# Altium schematic sheet MCU045A_Target_Device.SchDoc(MCU045A_Target_Device)
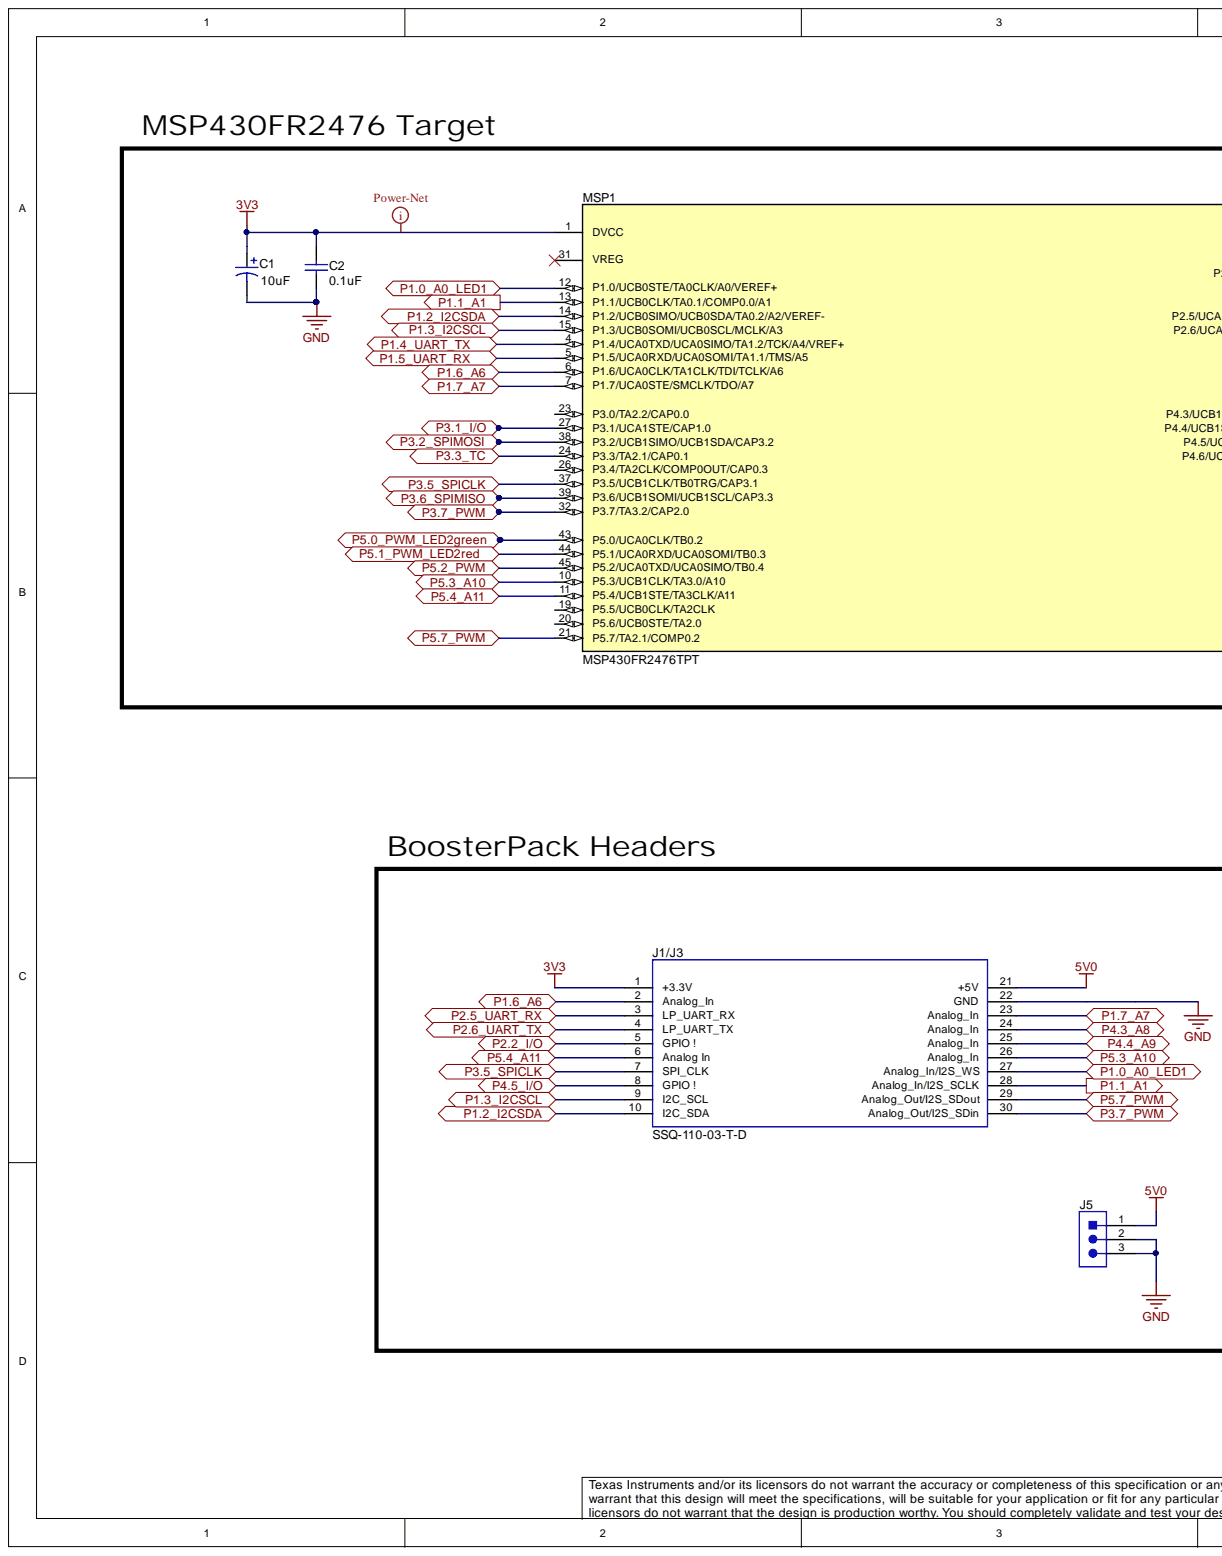
[diagram p0: MCU045A_Target_Device.SchDoc, left]
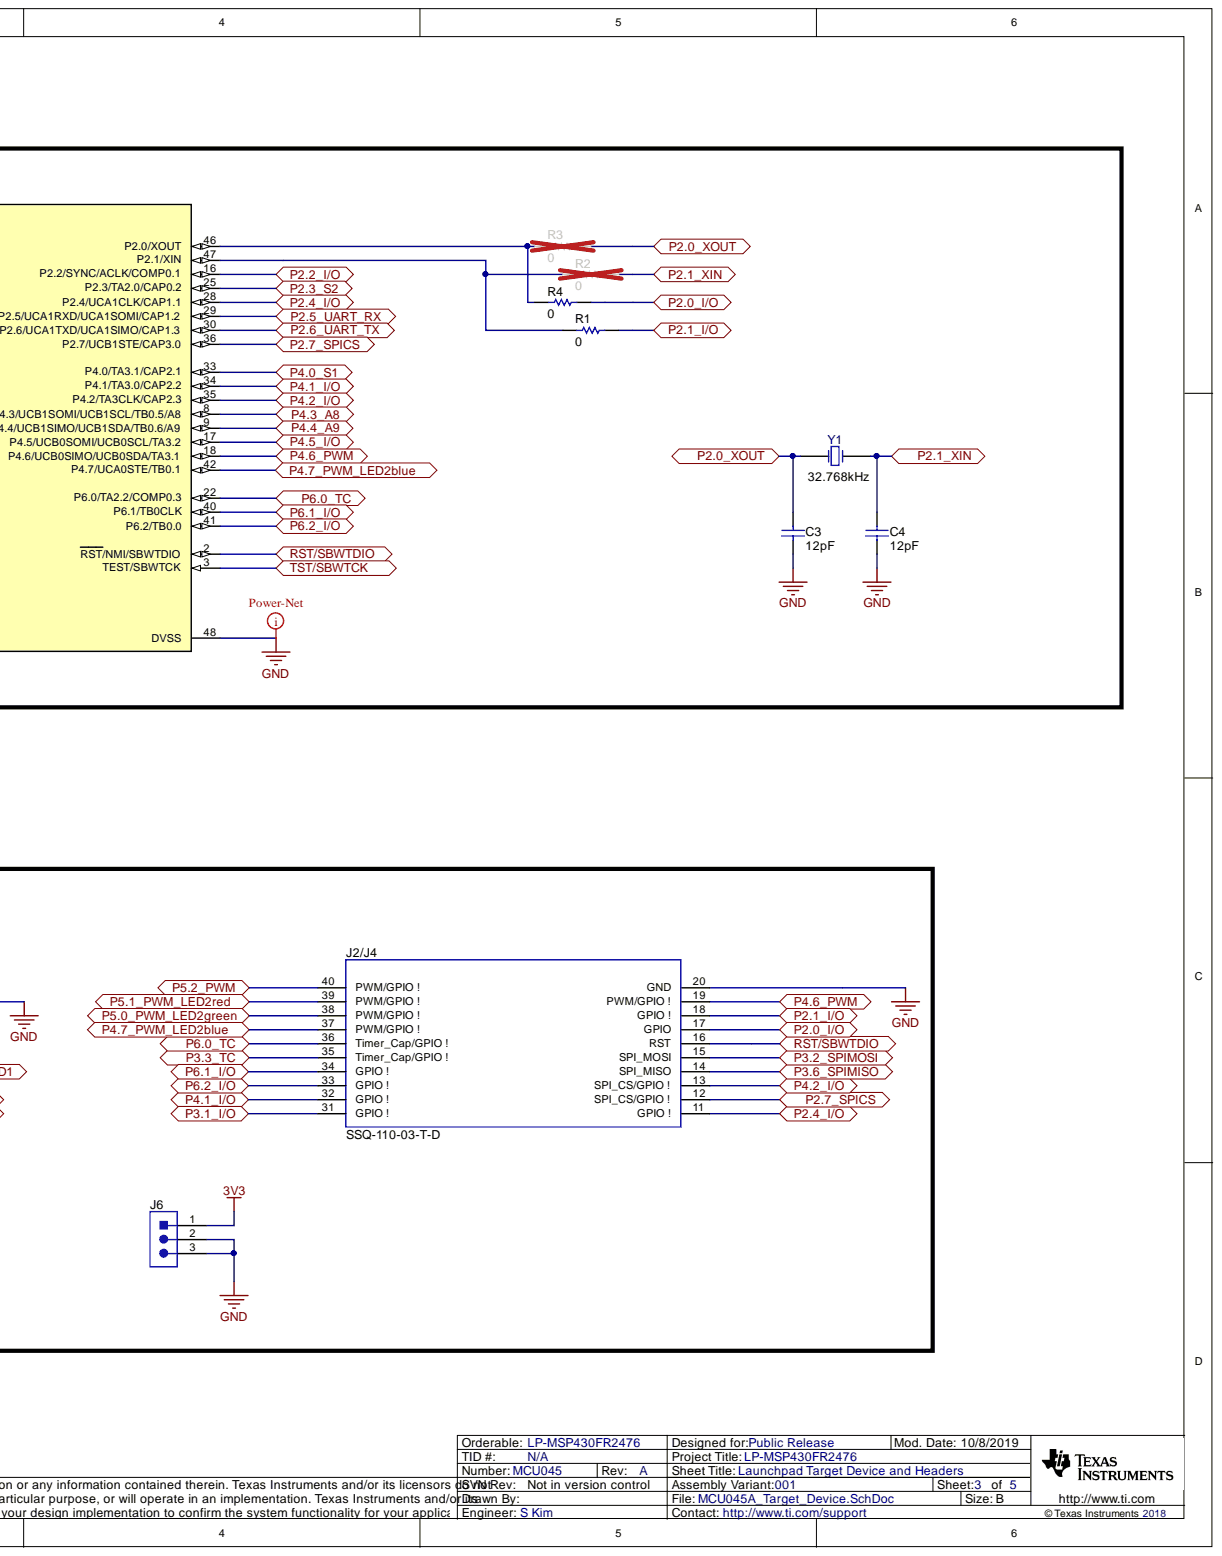
[diagram p1: MCU045A_Target_Device.SchDoc, right]

## Parts
C1  value 10uF  ValueDisplayed: 10uF  [3216-18]  (hidden comment: 293D106X0010A2TE3)
C2  value 0.1uF  ValueDisplayed: 0.1uF  [0402]  (hidden comment: GMK105BJ104KV-F)
C3  value 12pF  ValueDisplayed: 12pF  [0402]  (hidden comment: CL05C120JB5NNNC)
C4  value 12pF  ValueDisplayed: 12pF  [0402]  (hidden comment: CL05C120JB5NNNC)
J1/J3  SSQ-110-03-T-D  [BoosterPack_40pin_J1J3]
J2/J4  SSQ-110-03-T-D  [BoosterPack_40pin_J2J4]
J5  PEC03SAAN  [PEC03SAAN_Launchpad_5V0]  (value not drawn)
J6  PEC03SAAN  [PEC03SAAN_Launchpad_3V3]  (value not drawn)
MSP1  MSP430FR2476TPT  [PT0048A_N]
R1  value 0  [0603]  (hidden comment: CRCW06030000Z0EA)
R2  value 0  [0603]  (hidden comment: CRCW06030000Z0EA)
R3  value 0  [0603]  (hidden comment: CRCW06030000Z0EA)
R4  value 0  [0603]  (hidden comment: CRCW06030000Z0EA)
Y1  Frequency_Fixed: 32.768kHz  [ECS_ECX_31B]  (hidden comment: ECS-.327-12.5-34B-TR)

## Ports
P1.0_A0_LED1, P1.1_A1, P1.2_I2CSDA, P1.3_I2CSCL, P1.4_UART_TX, P1.5_UART_RX, P1.6_A6, P1.7_A7, P2.0_I/O, P2.0_XOUT, P2.1_I/O, P2.1_XIN, P2.2_I/O, P2.3_S2, P2.4_I/O, P2.5_UART_RX, P2.6_UART_TX, P2.7_SPICS, P3.1_I/O, P3.2_SPIMOSI, P3.3_TC, P3.5_SPICLK, P3.6_SPIMISO, P3.7_PWM, P4.0_S1, P4.1_I/O, P4.2_I/O, P4.3_A8, P4.4_A9, P4.5_I/O, P4.6_PWM, P4.7_PWM_LED2blue, P5.0_PWM_LED2green, P5.1_PWM_LED2red, P5.2_PWM, P5.3_A10, P5.4_A11, P5.7_PWM, P6.0_TC, P6.1_I/O, P6.2_I/O, RST/SBWTDIO, TST/SBWTCK

## Nets
3V3: C1.1 C2.1 J1/J3.1(+3.3V) J6.1 MSP1.1(DVCC)
5V0: J1/J3.21(+5V) J5.1
GND: C1.2 C2.2 C3.1 C4.1 J1/J3.22(GND) J2/J4.20(GND) J5.2 J5.3 J6.2 J6.3 MSP1.48(DVSS)
P1.0_A0_LED1: J1/J3.27(Analog_In/I2S_WS) MSP1.12(P1.0/UCB0STE/TA0CLK/A0/VEREF+) ; port P1.0_A0_LED1
P1.1_A1: J1/J3.28(Analog_In/I2S_SCLK) MSP1.13(P1.1/UCB0CLK/TA0.1/COMP0.0/A1) ; port P1.1_A1
P1.2_I2CSDA: J1/J3.10(I2C_SDA) MSP1.14(P1.2/UCB0SIMO/UCB0SDA/TA0.2/A2/VEREF-) ; port P1.2_I2CSDA
P1.3_I2CSCL: J1/J3.9(I2C_SCL) MSP1.15(P1.3/UCB0SOMI/UCB0SCL/MCLK/A3) ; port P1.3_I2CSCL
P1.4_UART_TX: MSP1.4(P1.4/UCA0TXD/UCA0SIMO/TA1.2/TCK/A4/VREF+) ; port P1.4_UART_TX
P1.5_UART_RX: MSP1.5(P1.5/UCA0RXD/UCA0SOMI/TA1.1/TMS/A5) ; port P1.5_UART_RX
P1.6_A6: J1/J3.2(Analog_In) MSP1.6(P1.6/UCA0CLK/TA1CLK/TDI/TCLK/A6) ; port P1.6_A6
P1.7_A7: J1/J3.23(Analog_In) MSP1.7(P1.7/UCA0STE/SMCLK/TDO/A7) ; port P1.7_A7
P2.0_I/O: J2/J4.17(GPIO) R4.2 ; port P2.0_I/O
P2.0_XOUT: C3.2 R3.2 Y1.2 ; port P2.0_XOUT
P2.1_I/O: J2/J4.18(GPIO_!) R1.2 ; port P2.1_I/O
P2.1_XIN: C4.2 R2.2 Y1.1 ; port P2.1_XIN
P2.2_I/O: J1/J3.5(GPIO_!) MSP1.16(P2.2/SYNC/ACLK/COMP0.1) ; port P2.2_I/O
P2.3_S2: MSP1.25(P2.3/TA2.0/CAP0.2) ; port P2.3_S2
P2.4_I/O: J2/J4.11(GPIO_!) MSP1.28(P2.4/UCA1CLK/CAP1.1) ; port P2.4_I/O
P2.5_UART_RX: J1/J3.3(LP_UART_RX) MSP1.29(P2.5/UCA1RXD/UCA1SOMI/CAP1.2) ; port P2.5_UART_RX
P2.6_UART_TX: J1/J3.4(LP_UART_TX) MSP1.30(P2.6/UCA1TXD/UCA1SIMO/CAP1.3) ; port P2.6_UART_TX
P2.7_SPICS: J2/J4.12(SPI_CS/GPIO_!) MSP1.36(P2.7/UCB1STE/CAP3.0) ; port P2.7_SPICS
P3.1_I/O: J2/J4.31(GPIO_!) MSP1.27(P3.1/UCA1STE/CAP1.0) ; port P3.1_I/O
P3.2_SPIMOSI: J2/J4.15(SPI_MOSI) MSP1.38(P3.2/UCB1SIMO/UCB1SDA/CAP3.2) ; port P3.2_SPIMOSI
P3.3_TC: J2/J4.35(Timer_Cap/GPIO_!) MSP1.24(P3.3/TA2.1/CAP0.1) ; port P3.3_TC
P3.5_SPICLK: J1/J3.7(SPI_CLK) MSP1.37(P3.5/UCB1CLK/TB0TRG/CAP3.1) ; port P3.5_SPICLK
P3.6_SPIMISO: J2/J4.14(SPI_MISO) MSP1.39(P3.6/UCB1SOMI/UCB1SCL/CAP3.3) ; port P3.6_SPIMISO
P3.7_PWM: J1/J3.30(Analog_Out/I2S_SDin) MSP1.32(P3.7/TA3.2/CAP2.0) ; port P3.7_PWM
P4.0_S1: MSP1.33(P4.0/TA3.1/CAP2.1) ; port P4.0_S1
P4.1_I/O: J2/J4.32(GPIO_!) MSP1.34(P4.1/TA3.0/CAP2.2) ; port P4.1_I/O
P4.2_I/O: J2/J4.13(SPI_CS/GPIO_!) MSP1.35(P4.2/TA3CLK/CAP2.3) ; port P4.2_I/O
P4.3_A8: J1/J3.24(Analog_In) MSP1.8(P4.3/UCB1SOMI/UCB1SCL/TB0.5/A8) ; port P4.3_A8
P4.4_A9: J1/J3.25(Analog_In) MSP1.9(P4.4/UCB1SIMO/UCB1SDA/TB0.6/A9) ; port P4.4_A9
P4.5_I/O: J1/J3.8(GPIO_!) MSP1.17(P4.5/UCB0SOMI/UCB0SCL/TA3.2) ; port P4.5_I/O
P4.6_PWM: J2/J4.19(PWM/GPIO_!) MSP1.18(P4.6/UCB0SIMO/UCB0SDA/TA3.1) ; port P4.6_PWM
P4.7_PWM_LED2blue: J2/J4.37(PWM/GPIO_!) MSP1.42(P4.7/UCA0STE/TB0.1) ; port P4.7_PWM_LED2blue
P5.0_PWM_LED2green: J2/J4.38(PWM/GPIO_!) MSP1.43(P5.0/UCA0CLK/TB0.2) ; port P5.0_PWM_LED2green
P5.1_PWM_LED2red: J2/J4.39(PWM/GPIO_!) MSP1.44(P5.1/UCA0RXD/UCA0SOMI/TB0.3) ; port P5.1_PWM_LED2red
P5.2_PWM: J2/J4.40(PWM/GPIO_!) MSP1.45(P5.2/UCA0TXD/UCA0SIMO/TB0.4) ; port P5.2_PWM
P5.3_A10: J1/J3.26(Analog_In) MSP1.10(P5.3/UCB1CLK/TA3.0/A10) ; port P5.3_A10
P5.4_A11: J1/J3.6(Analog_In) MSP1.11(P5.4/UCB1STE/TA3CLK/A11) ; port P5.4_A11
P5.7_PWM: J1/J3.29(Analog_Out/I2S_SDout) MSP1.21(P5.7/TA2.1/COMP0.2) ; port P5.7_PWM
P6.0_TC: J2/J4.36(Timer_Cap/GPIO_!) MSP1.22(P6.0/TA2.2/COMP0.3) ; port P6.0_TC
P6.1_I/O: J2/J4.34(GPIO_!) MSP1.40(P6.1/TB0CLK) ; port P6.1_I/O
P6.2_I/O: J2/J4.33(GPIO_!) MSP1.41(P6.2/TB0.0) ; port P6.2_I/O
RST/SBWTDIO: J2/J4.16(RST) MSP1.2(RST/NMI/SBWTDIO) ; port RST/SBWTDIO
TST/SBWTCK: MSP1.3(TEST/SBWTCK) ; port TST/SBWTCK
NetMSP1_46: MSP1.46(P2.0/XOUT) R3.1 R4.1
NetMSP1_47: MSP1.47(P2.1/XIN) R1.1 R2.1

Not connected: MSP1 19(P5.5/UCB0CLK/TA2CLK) 20(P5.6/UCB0STE/TA2.0) 23(P3.0/TA2.2/CAP0.0) 26(P3.4/TA2CLK/COMP0OUT/CAP0.3) 31(VREG)

## Library data of the parts
C1 = CMP-0011447-2: CAP, TA, 10 uF, 10 V, +/- 20%, 3.4 ohm, SMD; Manufacturer: Vishay-Sprague; VDC_V: 10V; Value1: 3.4 ohm; U/M: EA; TaMin_C: -55°C; TaMax_C: 125°C; SizeZ_mm: 1.8; SizeY_mm: 1.6; SizeX_mm: 3.2; SizeAreaWB_mm2: 10.92; SizeArea_mm2: 5.12; Polarized: Y; IRMS_A: 1.5dA; ESR_ohm: 3.4; CompType: Capacitor; Cap_F: 10µF; Cap_Case: A; AEC Category: Q200; Value2: 10V; Tolerance_%: 20%; Technology: TA; Supplier Part Number 3: 74-293D106X0010A2TE3; Supplier Part Number 2: 09K5784; Supplier Part Number 1: 718-1118-1-ND; Supplier 3: Mouser; Supplier 2: Newark; Supplier 1: Digi-Key; Series: 293D; RoHS: Y; PartNumber: 293D106X0010A2TE3; PackageReference: 3216-18; MountType: SMT; Library Name: Altium Vault - TI; LatestRevisionNote: Updated supplier links; LatestRevisionDate: 2017-03-09; ComponentLink1URL: http://www.vishay.com/docs/40002/293d.pdf; ComponentLink1Description: Datasheet link; Component Kind: Standard; AEC Qualified: ?; Publisher: Texas Instruments
C2 = CMP-0006370-2: CAP, CERM, 0.1 uF, 35 V, +/- 10%, X5R, 0402; Manufacturer: Taiyo Yuden; VDC_V: 35V; Value1: Unknown; U/M: EA; TaMin_C: -55°C; TaMax_C: 85°C; SizeZ_mm: 0.6; SizeY_mm: 0.5; SizeX_mm: 1; SizeAreaWB_mm2: 3; Polarized: N; CompType: Capacitor; Cap_F: 100nF; AEC Category: Q200; Value2: 35V; Tolerance_%: 10%; TempCo: X5R; Technology: Ceramic; Supplier Part Number 3: 963-GMK105BJ104KV-F; Supplier Part Number 2: 84R9117; Supplier Part Number 1: 587-1994-1-ND; Supplier 3: Mouser; Supplier 2: Newark; Supplier 1: Digi-Key; SizeArea_mm2: 0.5; RoHS: Y; Published: 2008-06-19; PartNumber: GMK105BJ104KV-F; PackageReference: 0402; MountType: SMT; Library Name: Altium Vault - TI; LatestRevisionDate: 2017-03-09; ComponentLink1URL: http://www.yuden.co.jp/productdata/catalog/en/mlcc_all_e.pdf; ComponentLink1Description: Datasheet link; Component Kind: Standard; Cap_Case: 0402; AEC Qualified: N; AEC Grade: N/A; Publisher: Texas Instruments
C3 C4 = CMP-0006345-2: CAP, CERM, 12 pF, 50 V, +/- 5%, C0G/NP0, 0402; Manufacturer: Samsung Electro-Mechanics; VDC_V: 50V; Value1: Unknown; U/M: EA; TaMin_C: -55°C; TaMax_C: 125°C; SizeZ_mm: 0.6; SizeY_mm: 0.5; SizeX_mm: 1; SizeAreaWB_mm2: 3; Polarized: N; CompType: Capacitor; AEC Category: Q200; Value2: 50V; Tolerance_%: 5%; TempCo: C0G/NP0; Technology: Ceramic; Supplier Part Number 1: 1276-1178-1-ND; Supplier 1: Digi-Key; SizeArea_mm2: 0.5; RoHS: Y; Published: 2008-06-19; PartNumber: CL05C120JB5NNNC; PackageReference: 0402; MountType: SMT; Library Name: Altium Vault - TI; LatestRevisionDate: 2017-03-09; ComponentLink1URL: http://www.samsungsem.com/servlet/FileDownload?type=spec&file=CL05C120JB5NNNC.pdf; ComponentLink1Description: Datasheet link; Component Kind: Standard; Cap_F: 12pF; Cap_Case: 0402; AEC Qualified: N; AEC Grade: N/A; Publisher: Texas Instruments
J1/J3 = CMP-0003837-2: Receptacle, 2.54mm, 10x2, Tin, TH; Manufacturer: Samtec; Alternate PartNumber: CRD-081413-A-G; Alternate Manufacturer: Major League Electronics; Note: Booster Pack 40 pin J1 and J3; Green_REACh: Incomplete; Green_Lifecycle: Active; Supplier Part Number 2: 11P8392; Supplier Part Number 1: SAM1204-10-ND; Supplier 2: Newark; Supplier 1: Digi-Key; RoHS: Y; PartNumber: SSQ-110-03-T-D; PackageReference: 10x2 Receptacle; MountType: TH; Library Name: Altium Vault - TI; Green_EU RoHS-2: Passed; Green_EU RoHS: Passed; CompType: Receptacle; ComponentLink1URL: https://www.samtec.com/ftppub/cpdf/SSQ-1XX-XX-XXX-X-XX-XXX-XX-X-MKT.pdf; ComponentLink1Description: Datasheet Link; Component Kind: Standard
J2/J4 = CMP-0078266-2: Receptacle, 2.54mm, 10x2, Tin, TH; Manufacturer: Samtec; Alternate PartNumber: CRD-081413-A-G; Alternate Manufacturer: Major League Electronics; Note: Booster Pack 40 pin J2 and J4; Green_REACh: Incomplete; Green_Lifecycle: Active; Supplier Part Number 2: 11P8392; Supplier Part Number 1: SAM1204-10-ND; Supplier 2: Newark; Supplier 1: Digi-Key; RoHS: Y; PartNumber: SSQ-110-03-T-D; PackageReference: 10x2 Receptacle; MountType: TH; Library Name: Altium Vault - TI; Green_EU RoHS-2: Passed; Green_EU RoHS: Passed; CompType: Receptacle; ComponentLink1URL: https://www.samtec.com/ftppub/cpdf/SSQ-1XX-XX-XXX-X-XX-XXX-XX-X-MKT.pdf; ComponentLink1Description: Datasheet Link; Component Kind: Standard
J5 J6 = CMP-0002338-1: Header, 100mil, 3x1, Tin, TH; Manufacturer: Sullins Connector Solutions; Voltage_V: 1.8kV; Shrouded: N; Row_Count: 1; Pitch_mm: 2.54; Green_REACh: Incomplete; Green_Lifecycle: Not Set; Gender: Male; Current_A: 3A; CT: FALSE; CNHeader: TRUE; Cert_UL: Y; Supplier Part Number 1: S1012E-03-ND; Supplier 1: Digi-Key; RoHS: Y; PinCount: 3; PartNumber: PEC03SAAN; PackageReference: Header, 3 PIN, 100mil, Tin; Orientation: Vertical; MountType: TH; Library Name: Altium Vault - TI; LatestRevisionDate: 26/05/2017; Green_EU RoHS-2: Incomplete; Green_EU RoHS: Incomplete; ContactFinish: Tin; CompType: Header; ComponentLink1URL: http://www.sullinscorp.com/catalogs/77_PAGE108-109_.100_MALE_HDR.pdf; ComponentLink1Description: Datasheet URL; Component Kind: Standard; Color: Black; ClassName: Header; Publisher: Texas Instruments
MSP1 = CMP-0081772-1: Mixed-Signal Microcontroller, PT0048A (LQFP-48); Manufacturer: Texas Instruments; SizeZ_mm: 1.6; SizeY_mm: 9; SizeAreaWB_mm2: 121; SizeArea_mm2: 81; CompType: IC; AEC Qualified: N; AEC Category: Q200; SizeX_mm: 9; PartNumber: MSP430FR2476TPT; PackageReference: PT0048A; PackageDescription: LQFP-48; MountType: SMT; Library Name: Altium Vault - TI; ComponentLink3URL: http://www.ti.com/product/msp430fr247x/datasheet; ComponentLink3Description: Online Datasheet; ComponentLink2URL: http://www.ti.com/product/msp430fr247x; ComponentLink2Description: Product Folder; ComponentLink1URL: http://www.ti.com/lit/ds/symlink/msp430fr247x.pdf; ComponentLink1Description: Datasheet Link; Component Kind: Standard; Alternate Manufacturer: Texas Instruments
R1-R4 = CMP-0025697-4: RES, 0, 5%, 0.1 W, AEC-Q200 Grade 0, 0603; Manufacturer: Vishay-Dale; VDC_V: 75V; Value_Code: 0000; Value2: 0.1W; TempCo: 200 ppm/C; SizeZ_mm: 1; SizeY_mm: 0.8; SizeX_mm: 1.6; SizeArea_mm2: 1.28; Power_W: 100mW; EIA_Code: E24; CompType: Resistor; AEC Qualified: Y; AEC Category: Q200; ValueDisplayed: 0; Value1: 5%; Tolerance_%: 5%; Technology: Thick Film; Supplier Part Number 3: 71-CRCW0603-0-E3; Supplier Part Number 2: 52K8014; Supplier Part Number 1: 541-0.0GCT-ND; Supplier 3: Mouser; Supplier 2: Newark; Supplier 1: Digi-Key; RoHS: E; Res_ohm: 0; PartNumber: CRCW06030000Z0EA; PackageReference: 0603; MountType: SMT; Library Name: Altium Vault - TI; ComponentLink1URL: http://www.vishay.com/docs/20035/dcrcwe3.pdf; ComponentLink1Description: Datasheet link; Component Kind: Standard; AEC Grade: 0
Y1 = CMP-0078856-1: Crystal, 32.768 KHz, 20 ppm, SMD; Manufacturer: ECS Inc.; Alternate PartNumber: FC-135 32.7680KA; Alternate Manufacturer: Epson; TaMin_C: -40°C; TaMax_C: 85°C; SizeZ_mm: 0.9; SizeY_mm: 1.5; SizeX_mm: 3.2; SizeAreaWB_mm2: 10.5; SizeArea_mm2: 4.8; Frequency Tolerance: 20 ppm; AEC Category: Q200; Supplier Part Number 2: 520-327-12.5-34B; Supplier Part Number 1: XC1617CT-ND; Supplier 2: Mouser; Supplier 1: Digi-Key; RoHS: Y; REACh: Y; PartNumber: ECS-.327-12.5-34B-TR; PackageReference: 3.2x1.5mm; MountType: SMT; Library Name: Altium Vault - TI; CompType: Crystal; ComponentLink1URL: http://www.ecsxtal.com/store/pdf/ecx-31b.pdf; ComponentLink1Description: Datasheet Link; Component Kind: Standard; AEC Qualified: ?
